# Altium schematic sheet PSU.SchDoc(PSU)
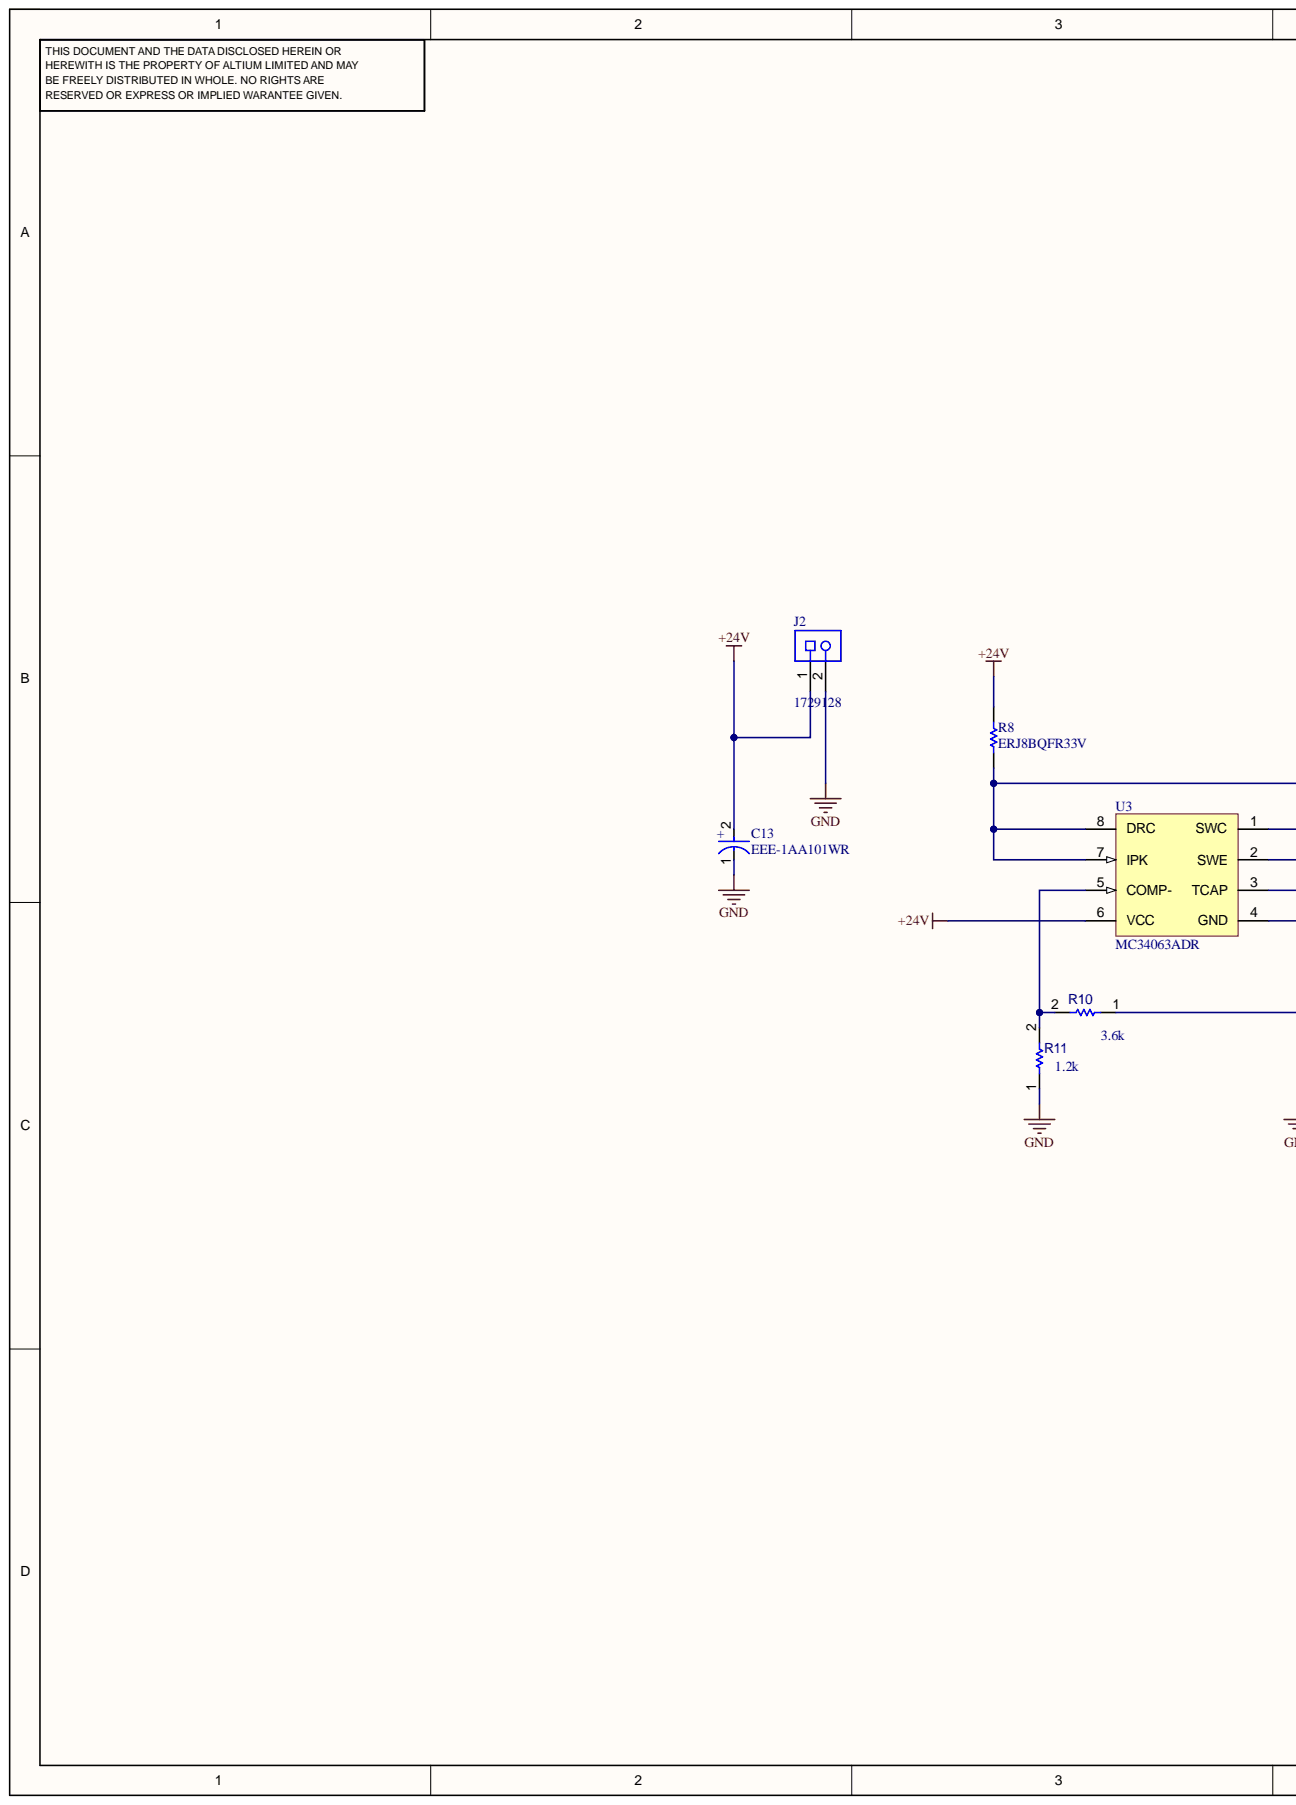
[diagram p0: PSU.SchDoc, left]
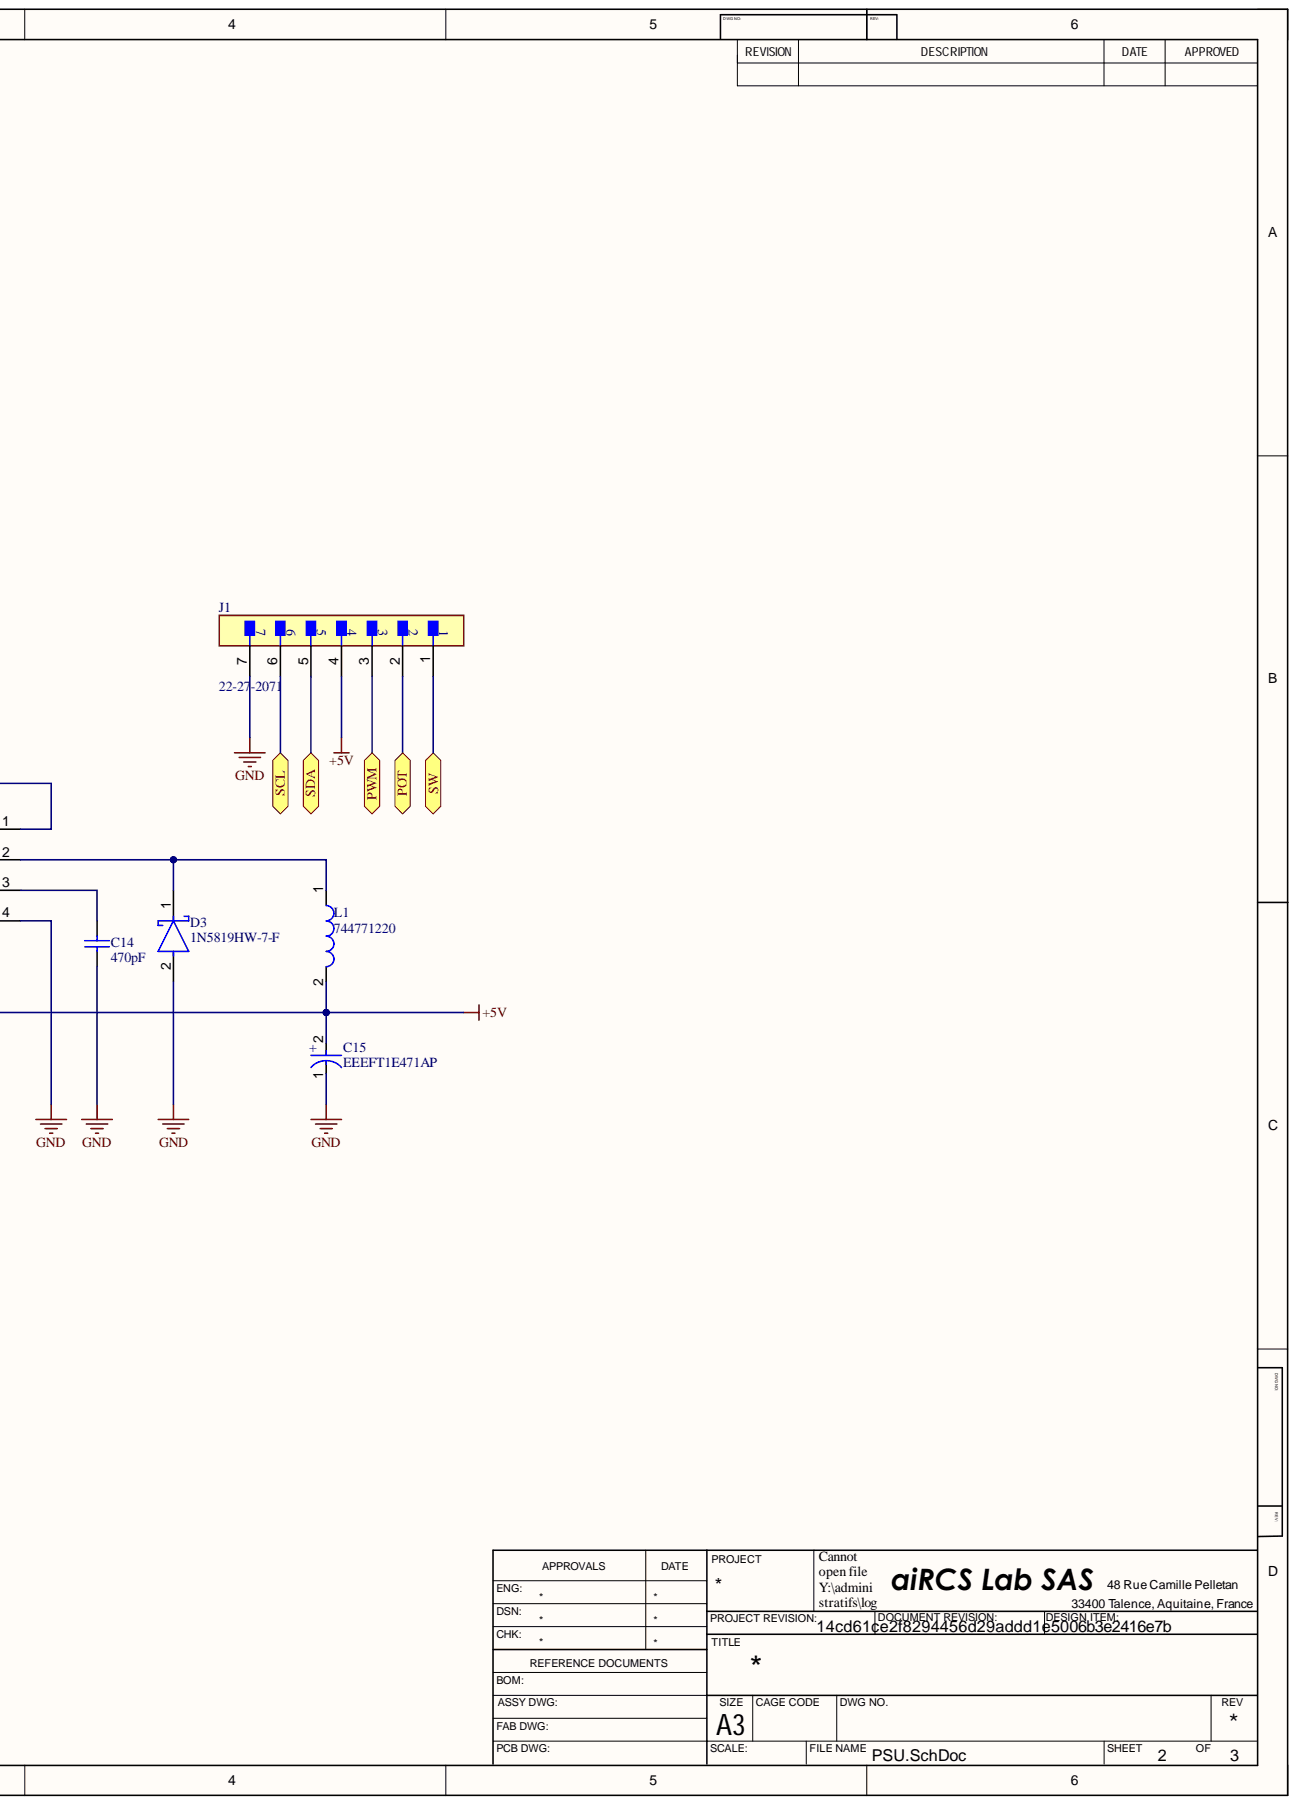
[diagram p1: PSU.SchDoc, right]

## Parts
C13  EEE-1AA101WR  [FP-SC-MFG]
C14  470pF  [CAPC1608X90X35NL10T15]
C15  EEEFT1E471AP  [FP-FTF-MFG]
D3  1N5819HW-7-F  [FP-SOD123-IPC_B]
J1  22-27-2071  [FP-22-27-2071-MFG]
J2  1729128  [PHOE-1729128-2_V]
L1  744771220  [WE-PD-L]
R8  ERJ8BQFR33V  [RESC3216X70X50NL05T20]
R10  3.6k  [RESC0402(1005)_L]
R11  1.2k  [RESC0402(1005)_L]
U3  MC34063ADR  [D0008A_M]

## Ports
POT, PWM, SCL, SDA, SW

## Nets
+5V: C15.2 J1.4 L1.2 R10.1
+24V: C13.2 J2.1 R8.2 U3.6(VCC)
GND: C13.1 C14.1 C15.1 D3.2 J1.7 J2.2 R11.1 U3.4(GND)
POT: J1.2 ; port POT
PWM: J1.3 ; port PWM
SCL: J1.6 ; port SCL
SDA: J1.5 ; port SDA
SW: J1.1 ; port SW
NetC14_2: C14.2 U3.3(TCAP)
NetD3_1: D3.1 L1.1 U3.2(SWE)
NetR8_1: R8.1 U3.1(SWC) U3.7(IPK) U3.8(DRC)
NetR10_2: R10.2 R11.2 U3.5(COMP-)

## Library data of the parts
C13 = CMP-05427-000047-1: CAP ALUM 100UF 20% 10V SMD; Life (Hours): 1000Hour; Ripple Current: 50mA; Capacitance: 100uF; Case/Package: Radial; Component Kind: Standard; Construction: Cylindrical; Contact Plating: Tin; Diameter: 5mm; Height: 5.4mm; Height - Seated (Max): 0.213inch; Lead Free: Lead Free; Length: 5.5mm; Library Name: Altium Content Vault; Max Operating Temperature: 85°C; Min Operating Temperature: -40°C; Mount: Surface Mount; Package Quantity: 1; Packaging: Tape and Reel; Radiation Hardening: No; Ripple Current (AC): 50mA; RoHS Compliant: Yes; Series: S; Termination: SMD/SMT; Tolerance: 20%; Voltage Rating: 10V; Voltage Rating (DC): 10V
C14 = CMP-2006-02921-1: Manufacturer: Murata; Part Number: GRM188R71H471KA01D; Component Kind: Standard; Library Name: Altium Content Vault
C15 = CMP-05427-000040-1: CAP ALUM 470UF 20% 25V SMD; Dielectric Material: Aluminium; ESR (Equivalent Series Resistance): 80mR; Lead Diameter: 0.9mm; Leakage Current: 117.5uA; Life (Hours): 2000Hour; Ripple Current: 850mA; Capacitance: 470uF; Case/Package: Radial; Component Kind: Standard; Construction: Cylindrical; Contact Plating: Tin; Diameter: 8mm; Features: General Purpose; Height: 10.2mm; Height - Seated (Max): 0.413inch; Lead Free: Lead Free; Lead Pitch: 3.1mm; Length: 10.2mm; Library Name: Altium Content Vault; Max Operating Temperature: 105°C; Min Operating Temperature: -55°C; Mount: Surface Mount; Number of Pins: 2; Package Quantity: 500; Packaging: Tape and Reel; Radiation Hardening: No; REACH SVHC: No SVHC; Ripple Current (AC): 850mA; RoHS Compliant: Yes; Series: FT; Termination: SMD/SMT; Tolerance: 20%; Voltage: 25V; Voltage Rating: 25V; Voltage Rating (DC): 25V
D3 = CMP-0303-00003-3: DIODE SCHOTTKY 40V 1A SOD123; Capacitance: 110pF; Element Configuration: Single; Forward Voltage: 450mV; Max Forward Surge Current (Ifsm): 25A; Max Power Dissipation: 450mW; Peak Reverse Current: 50uA; Polarity: Standard; Average Rectified Current: 1A; Case/Package: SOD-123-2; Component Kind: Standard; Contact Plating: Tin; Current Rating: 1A; Forward Current: 1A; Height: 1.25mm; Lead Free: Lead Free; Length: 2.85mm; Library Name: Altium Content Vault; Max Operating Temperature: 125°C; Max Repetitive Reverse Voltage (Vrrm): 40V; Max Reverse Voltage (DC): 40V; Min Operating Temperature: -65°C; Number of Pins: 2; Output Current: 1A; Package Quantity: 3000; Packaging: Tape and Reel; Peak Non-Repetitive Surge Current: 25A; Radiation Hardening: No; REACH SVHC: No SVHC; Termination: SMD/SMT; Voltage Rating (DC): 40V; Weight: 0.000353oz; Width: 1.7mm
J1 = CMP-04776-000328-1: CONN HEADER VERT 7POS 2.54MM; Connector Type: Male Pin; Contact Resistance: 10mR; Current Rating: 4A; Gender: Male; Glow Wire Compliant: Non-compliant; Insulation Resistance: 50GR; Max Voltage Rating (AC): 250V; Number of Circuits: 7; Color: Natural; Component Kind: Standard; Contact Material: Brass; Contact Mating Length: 0.295inch; Contact Plating: Tin; Contact Type: Male Pin; Depth: 5.8mm; Fastening Type: Friction; Flammability Rating: UL94 V-0; Halogen Free: Low Halogen; Height: 8.38mm; Housing Color: White; Housing Material: Nylon; Lead Free: Lead Free; Length: 5.08mm; Library Name: Altium Content Vault; Max Current Rating: 4A; Max Operating Temperature: 75°C; Min Operating Temperature: 0°C; Mount: Through Hole; Number of Contacts: 7; Number of Positions: 7; Number of Rows: 1; Orientation: Straight; Package Quantity: 10; Packaging: Bulk; Pitch: 2.54mm; Radiation Hardening: No; RoHS Compliant: Yes; Series: KK; Termination: Solder; Voltage Rating: 250V; Voltage Rating (DC): 250V
J2 = CMP-2000-05815-1: PCB Terminal Block, 13.5 A, 400 V, 2-Pin THD, RoHS, Bulk; Manufacturer: Phoenix Contact; Current Rating: 13500mA; No. of Positions: 2; No. of Rows: 1; Package Version: 03/2015; Pitch: 5.08mm; Voltage Rating: 400V; Component Kind: Standard; Datasheet URL: https://datasheet.ciiva.com/16046/1729128-16046181.pdf; Datasheet Version: 12/2013; Library Name: Altium Content Vault; Manufacturer URL: http://www.phoenixcontact.com/; Mounting Technology: ThroughHole; Orientation: Horizontal; Package Description: 2-Pin Through Hole Device, Body 8.1 x 10.16 mm, Pitch 5.08 mm; Package Reference: 1729128-2; Package URL: https://www.phoenixcontact.com/online/portal/pi/pxc/product_detail_page/!ut/p/b1/3VdJjqNKFDxLH8AFycySyWY2ozFsEPOMMQaDOX1XedGL_1Vdm5YXJleknhSKeBkvI6EA8ncAo3AYoDhBQGco6KN7VURTdemj9us_IEKEZo_YHiPAEWEFmDGOHMORkqKYCORBZxgL7ZoaZYcbFFUZ3fqxSY6GOuxydWUuHqz1ynmMFygu583Bob0Ewr6Sd_l-5m-kAueHiB0jpRwZwe58wwtkpxtMYd_LmGcDRU02dRNEyWt6Y2ti5pSyJ_reIkzIulrqApdnbT11V5jnCKbYNCvhEM1MCpPByat_X379-iThf08CI5GfOPqfBeS3BRoBOdD55AKVv0iLwCMq-2BrzXWSGRH7pUGD9uwXQjiph3xL0DKQwdGa0JImiIOmBNOestqgwTHSSs2oOep-78apyXQBvNi8ZLaFjvjWvcaUEBu0MC6Wbe-d6mB0FAebo2lUWinqYaZs5DAYzV0RP9yitUjnGJ0iI9xhZkT42OCQNUJJhkgWINNQLP2JFP6OpMg3JCVg70jqHT0lvKOnhHf0lPuOnnLf0VPuWx6_dxwUIvwk5WMkT0kLL1mh61l2yZVSzG3pYV_bqo-mj6uMKwKwdnnW8Jh5RTOJzMOA5c-eJDaZQw4mTMPH02PvS_ZAqNVjVTo2WyYLkwH_KU1mmmG5kjRhDqPXLwwVHvVOjCM60-fpXvTDfMGW1PVFVS1r4e4yVDk4ZdNbkUHvqPqQSeian4UD39fNKmachqdJ1NJbyk8rXT9mXTvPk3oE5meulKGgiruPJek-4I9hTT6G8ZLOyRSm2RRVbThERQad5OS28hdt4YW4vG0stgYYzsWsK4_7y6DZMjJSCqri1kyiZR5vD7pwIrNR7QwPHTcLTI5qT7eRlpHrdGiupYlqQDJ7zy_CND8fWWBoLRXxk1RVcXiBQwbTD4Xi7KL-FuO94t9xaqweXzH; Packing: Bulk; PartNumber: 1729128; RoHS: TRUE
L1 = CMP-0227-00735-1: SMD-Shielded Power Inductor WE-PD, L = 220 µH; Inductance: 220 ÂµH; Component Kind: Standard; ComponentLink1Description: Manufacturer Link; ComponentLink1URL: http://www.we-online.com; ComponentLink2Description: Datasheet; ComponentLink2URL: http://www.we-online.de/katalog/media/pdf/744771220.pdf; DatasheetVersion: ver 6, Jun-2005; Library Name: Altium Content Vault; PackageDescription: SMD Inductor, 2-Leads, Body 12.0 x 12.0 mm; PackageReference: WE-PD-L; PackageVersion: 2006
R8 = CMP-2003-00751-1: Manufacturer: Panasonic; Component Kind: Standard; Library Name: Altium Content Vault; Part Number: ERJ8BQFR33V
R10 = CMP-009-00062-5: 3.8k; Case/Package: 0402; Component Kind: Standard; Mounting Technology: SMT; Pins: 2; Value: 3.6k; Library Name: Kariboo
R11 = CMP-009-00062-5: Case/Package: 0402; Component Kind: Standard; Description: 1.2k; Mounting Technology: SMT; Pins: 2; Value: 1.2k; Library Name: Kariboo
U3 = CMP-0323-00183-3: Buck Inverting Buck-Boost Regulator with 3 to 40 V Input and 1.55 to 40 V Output, 0 to 70 degC, 8-Pin SOIC (D), Green (RoHS & no Sb/Br); Manufacturer: Texas Instruments; Duty Cycle(Max)(%): 90; Iout(Max)(A): 0.75; Iq(Typ)(mA): 4; Rating: Catalog; Regulated Outputs(#): 1; SubFamily: Step-Down Regulator; Switch Current Limit(Typ)(A): 1.5; Topology: Buck, Inverting Buck-Boost; Vin(Max)(V): 40; Vin(Min)(V): 3; Vout(Min)(V): 1.55; Code_JEDEC: MS-012AA; Component Kind: Standard; ComponentLink1Description: Datasheet; ComponentLink1URL: http://www.ti.com/general/docs/lit/getliterature.tsp?genericPartNumber=MC34063A&fileType=pdf; ComponentLink2Description: Package Specification; ComponentLink2URL: http://www.ti.com/litv/pdf/msoi002j; ComponentLink3Description: URL Link1; ComponentLink3URL: Samples URL:http://www.ti.com/product/mc34063a#samples; DatasheetVersion: SLLS636M; Eco-Plan: Green (RoHS & no Sb/Br); Library Name: Altium Content Vault; Mounting Technology: Surface Mount; PackageDescription: 8-Pin Plastic Small Outline Integrated Circuit, 4.9 x 3.9 mm, Pitch 1.27 mm Pitch; PackageReference: D0008A; PackageVersion: revM, Jun-2011; PartNumber: MC34063ADR; Vout(Max)(V): 40
